# Altium schematic sheet transmitter.SchDoc
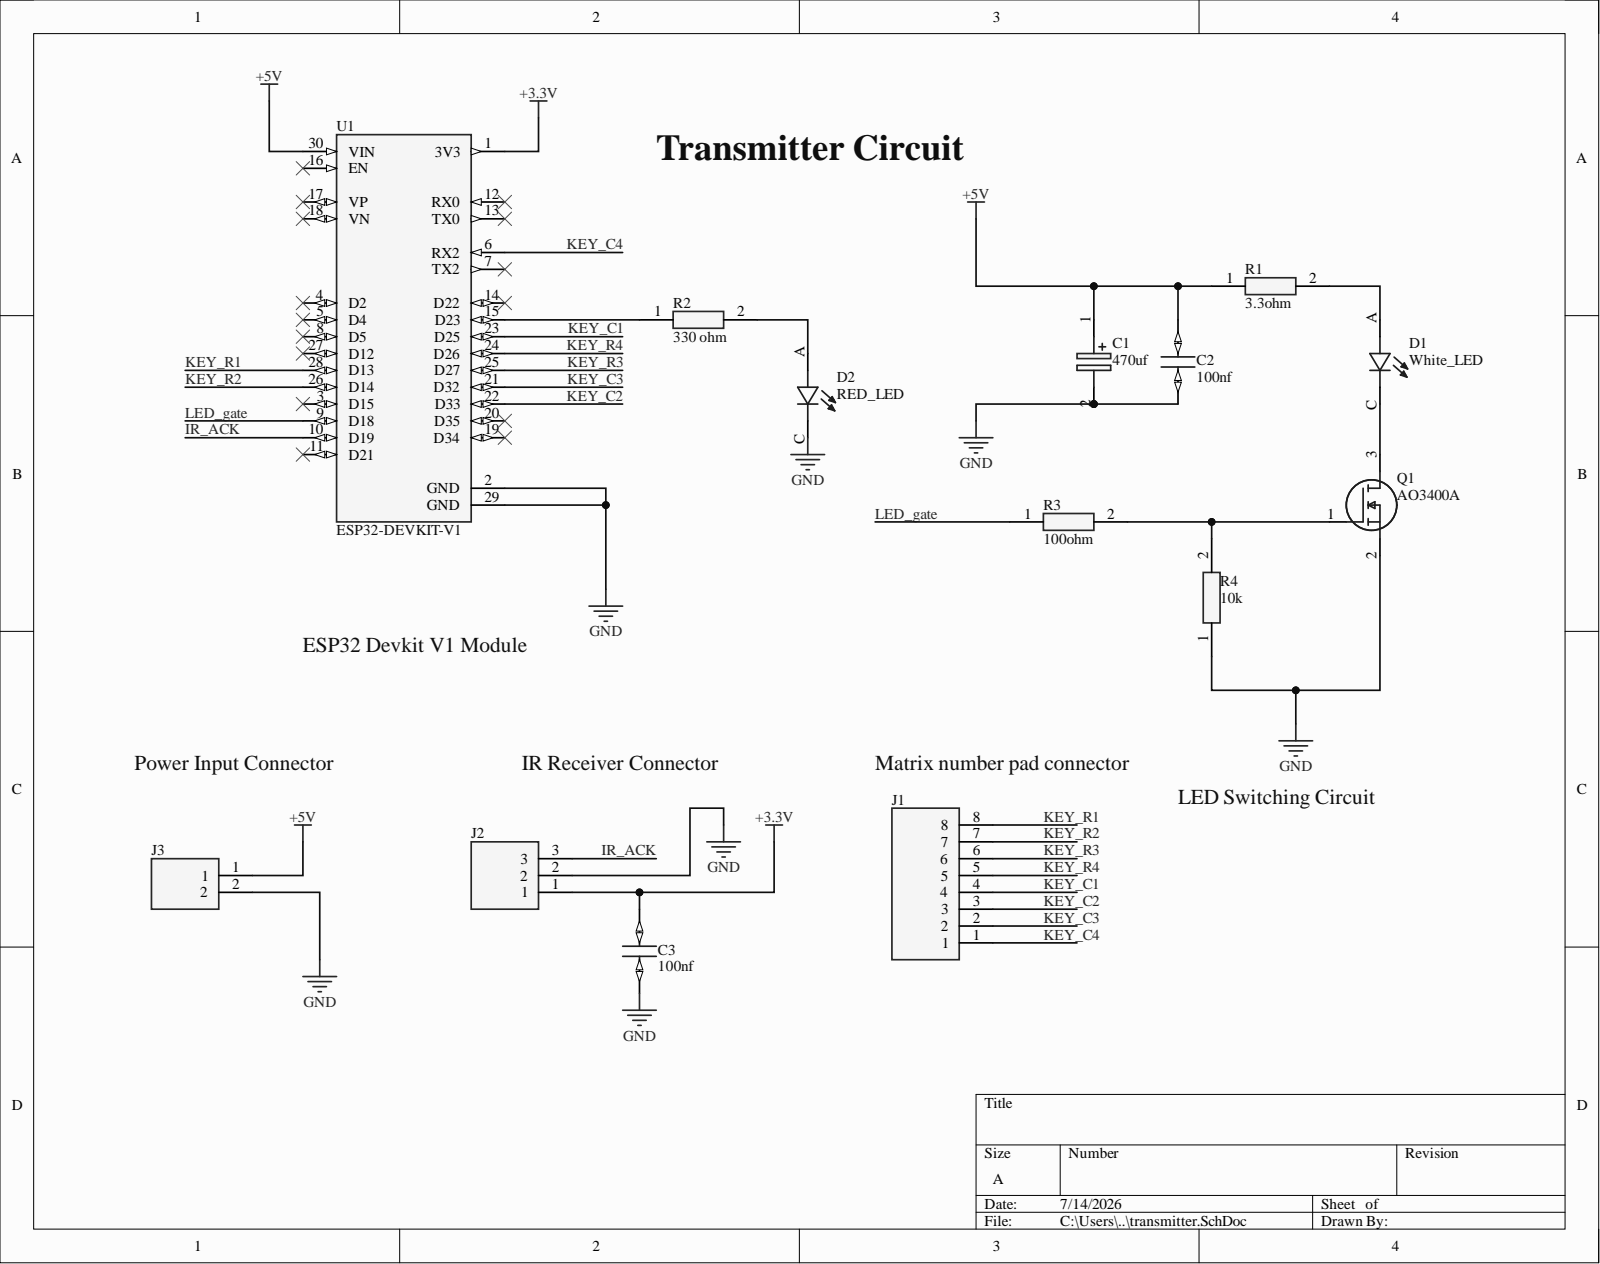
[diagram p0: transmitter.SchDoc]

## Parts
C1  470uf  [CAPPRD350W65D800H1300]
C2  100nf  [C320C]
C3  100nf  [C320C]
D1  White_LED  [LEDRD254W57D500H1070]
D2  RED_LED  [LEDRD254W57D500H1070]
J1  8 pin female header  [SHDR8W99P0X254_1X8_2017X635X1124P]  (value not drawn)
J2  801-93-003-10-001000  [RHDR3W60P0X254_1X3_762X254X701P]  (value not drawn)
J3  282834-2  [TE_282834-2]  (value not drawn)
Q1  AO3400A  [SOT95P280X125-3N]
R1  3.3ohm  [resistor]
R2  330 ohm  [resistor]
R3  100ohm  [resistor]
R4  10k  [resistor]
U1  ESP32-DEVKIT-V1  [MODULE_ESP32_DEVKIT_V1]

## Nets
+3.3V: C3.2 J2.1 U1.1(3V3)
+5V: C1.1 C2.2 J3.1 R1.1 U1.30(VIN)
GND: C1.2 C2.1 C3.1 D2.C J2.2 J3.2 Q1.2 R4.1 U1.2(GND) U1.29(GND)
IR_ACK: J2.3 U1.10(D19)
KEY_C1: J1.4 U1.23(D25)
KEY_C2: J1.3 U1.22(D33)
KEY_C3: J1.2 U1.21(D32)
KEY_C4: J1.1 U1.6(RX2)
KEY_R1: J1.8 U1.28(D13)
KEY_R2: J1.7 U1.26(D14)
KEY_R3: J1.6 U1.25(D27)
KEY_R4: J1.5 U1.24(D26)
LED_gate: R3.1 U1.9(D18)
NetD1_A: D1.A R1.2
NetD1_C: D1.C Q1.3
NetD2_A: D2.A R2.2
NetQ1_1: Q1.1 R3.2 R4.2
NetR2_1: R2.1 U1.15(D23)

Not connected: U1 3(D15) 4(D2) 5(D4) 7(TX2) 8(D5) 11(D21) 12(RX0) 13(TX0) 14(D22) 16(EN) 17(VP) 18(VN) 19(D34) 20(D35) 27(D12)

## Library data of the parts
C1 = 470uf: Panasonic 470uF 16 V dc Aluminium Electrolytic Capacitor; Manufacturer_Part_Number: EEU-FR1C471; Component Kind: Standard; Datasheet Link: http://industrial.panasonic.com/cdbs/www-data/pdf/RDF0000/ABA0000C1259.pdf; Height: 13mm; Library Name: Schlib1.SchLib; Manufacturer_Name: Panasonic; Mouser Part Number: 667-EEU-FR1C471; Mouser Price/Stock: https://www.mouser.co.uk/ProductDetail/Panasonic/EEU-FR1C471?qs=Uw8ySfEHS7KxXdGBPGtJqw%3D%3D
C2 C3 = C320C104M5U5TA: MF: KEMET; Check_prices: https://www.snapeda.com/parts/C320C104M5U5TA/KEMET/view-part/?ref=eda; Component Kind: Standard; Library Name: Schlib1.SchLib; MP: C320C104M5U5TA; Package: Radial KEMET; SnapEDA_Link: https://www.snapeda.com/parts/C320C104M5U5TA/KEMET/view-part/?ref=snap
D1 D2 = L08R5000Q1: LED, 5MM, ORANGE; LED / Lamp Size: 5mm / T-1 3/4; LED Colour: Orange; Typ Luminous Intensity: 4.3mcd; Viewing Angle: ...; MF: LED Technology; Availability: In Stock; Check_prices: https://www.snapeda.com/parts/L08R5000Q1/LED+Technology/view-part/?ref=eda; Component Kind: Standard; Library Name: Schlib1.SchLib; MP: L08R5000Q1; Package: None; Price: None; SnapEDA_Link: https://www.snapeda.com/parts/L08R5000Q1/LED+Technology/view-part/?ref=snap
J1 = 8 pin female header: Headers & Wire Housings KK Hdr Vrt 8 Ckt 2.54mm Pitch Sn; Manufacturer_Name: Molex; Manufacturer_Part_Number: 171856-0008; Component Kind: Standard; Datasheet Link: https://www.molex.com/pdm_docs/sd/1718560008_sd.pdf; Height: 11.24mm; Library Name: Schlib1.SchLib; Mouser Part Number: 538-171856-0008; Mouser Price/Stock: https://www.mouser.co.uk/ProductDetail/Molex/171856-0008?qs=vOeJqewp7jDqDQmQ40X2Tw%3D%3D
J2 = 801-93-003-10-001000: 3 Position Receptacle Connector 0.100" (2.54mm) Through Hole Gold; Manufacturer_Name: Mill-Max; Component Kind: Standard; Datasheet Link: https://www.mill-max.com/products/datasheet/sockets/801-93-003-10-001000; Height: 7.01mm; Library Name: Schlib1.SchLib; Manufacturer_Part_Number: 801-93-003-10-001000; Mouser Part Number: 575-80193311; Mouser Price/Stock: http://www.mouser.com/Search/ProductDetail.aspx?qs=WZRMhwwaLl9KB4BbTGocnQ%3d%3d
J3 = 282834-2: Terminal Block Connector PCB 2.54 mm 30-16 AWG Green 10 A 150 V M1.6 | TE Connectivity 282834-2; Centerline_Pitch: 2.54 mm[.1 in]; Number_of_Positions: 2; Check_prices: https://www.snapeda.com/parts/282834-2/TE+Connectivity+AMP+Connectors/view-part/?ref=eda; Component Kind: Standard; EU_RoHS_Compliance: Compliant with Exemptions; Library Name: Schlib1.SchLib; MF: TE Connectivity; MP: 282834-2; Package: None; SnapEDA_Link: https://www.snapeda.com/parts/282834-2/TE+Connectivity+AMP+Connectors/view-part/?ref=snap
Q1 = AO3400A: 30V N-Channel MOSFET; Manufacturer_Name: Alpha & Omega Semiconductors; Arrow Part Number: AO3400A; Arrow Price/Stock: https://www.arrow.com/en/products/ao3400a/alpha-and-omega-semiconductor?utm_currency=USD&region=nac; Component Kind: Standard; Datasheet Link: http://aosmd.com/res/data_sheets/AO3400A.pdf; Height: 1.25mm; Library Name: Schlib1.SchLib; Manufacturer_Part_Number: AO3400A
R1 R2 = 3.3ohm resistor throuh hole_1_1: Resistor; Manufacturer_Name: KEMET; Manufacturer_Part_Number: CFR-25JB-52-10K; Arrow Part Number: CFR-25JB-52-10K; Arrow Price/Stock: https://www.arrow.com/en/products/cfr-25jb-52-10k/yageo; Component Kind: Standard; Datasheet Link: https://datasheet.datasheetarchive.com/originals/distributors/Datasheets_SAMA/cf5c7c7b14e59499e9125003e6ee5ce2.pdf; Library Name: Schlib1.SchLib; Mouser Part Number: 603-CFR-25JB-52-10K; Mouser Price/Stock: https://www.mouser.co.uk/ProductDetail/YAGEO/CFR-25JB-52-10K?qs=oypCK0zG32646UD7yHncYg%3D%3D
R3 = 100ohm resistor throuh hole_1_1: Resistor; Manufacturer_Name: KEMET; Manufacturer_Part_Number: CFR-25JB-52-10K; Arrow Part Number: CFR-25JB-52-10K; Arrow Price/Stock: https://www.arrow.com/en/products/cfr-25jb-52-10k/yageo; Component Kind: Standard; Datasheet Link: https://datasheet.datasheetarchive.com/originals/distributors/Datasheets_SAMA/cf5c7c7b14e59499e9125003e6ee5ce2.pdf; Library Name: Schlib1.SchLib; Mouser Part Number: 603-CFR-25JB-52-10K; Mouser Price/Stock: https://www.mouser.co.uk/ProductDetail/YAGEO/CFR-25JB-52-10K?qs=oypCK0zG32646UD7yHncYg%3D%3D
R4 = 10k resistor throuh hole_1: Resistor; Manufacturer_Name: KEMET; Manufacturer_Part_Number: CFR-25JB-52-10K; Arrow Part Number: CFR-25JB-52-10K; Arrow Price/Stock: https://www.arrow.com/en/products/cfr-25jb-52-10k/yageo; Component Kind: Standard; Datasheet Link: https://datasheet.datasheetarchive.com/originals/distributors/Datasheets_SAMA/cf5c7c7b14e59499e9125003e6ee5ce2.pdf; Library Name: Schlib1.SchLib; Mouser Part Number: 603-CFR-25JB-52-10K; Mouser Price/Stock: https://www.mouser.co.uk/ProductDetail/YAGEO/CFR-25JB-52-10K?qs=oypCK0zG32646UD7yHncYg%3D%3D
U1 = ESP32-DEVKIT-V1: Dual core, Wi-Fi: 2.4 GHz up to 150 Mbits/s,BLE (Bluetooth Low Energy) and legacy Bluetooth, 32 bits, Up to 240 MHz; MF: Do it; Check_prices: https://www.snapeda.com/parts/ESP32-DEVKIT-V1/Do+it/view-part/?ref=eda; Component Kind: Standard; Library Name: Schlib1.SchLib; MP: ESP32-DEVKIT-V1; Package: None; SnapEDA_Link: https://www.snapeda.com/parts/ESP32-DEVKIT-V1/Do+it/view-part/?ref=snap
